# Altium schematic sheet power.SchDoc(power)
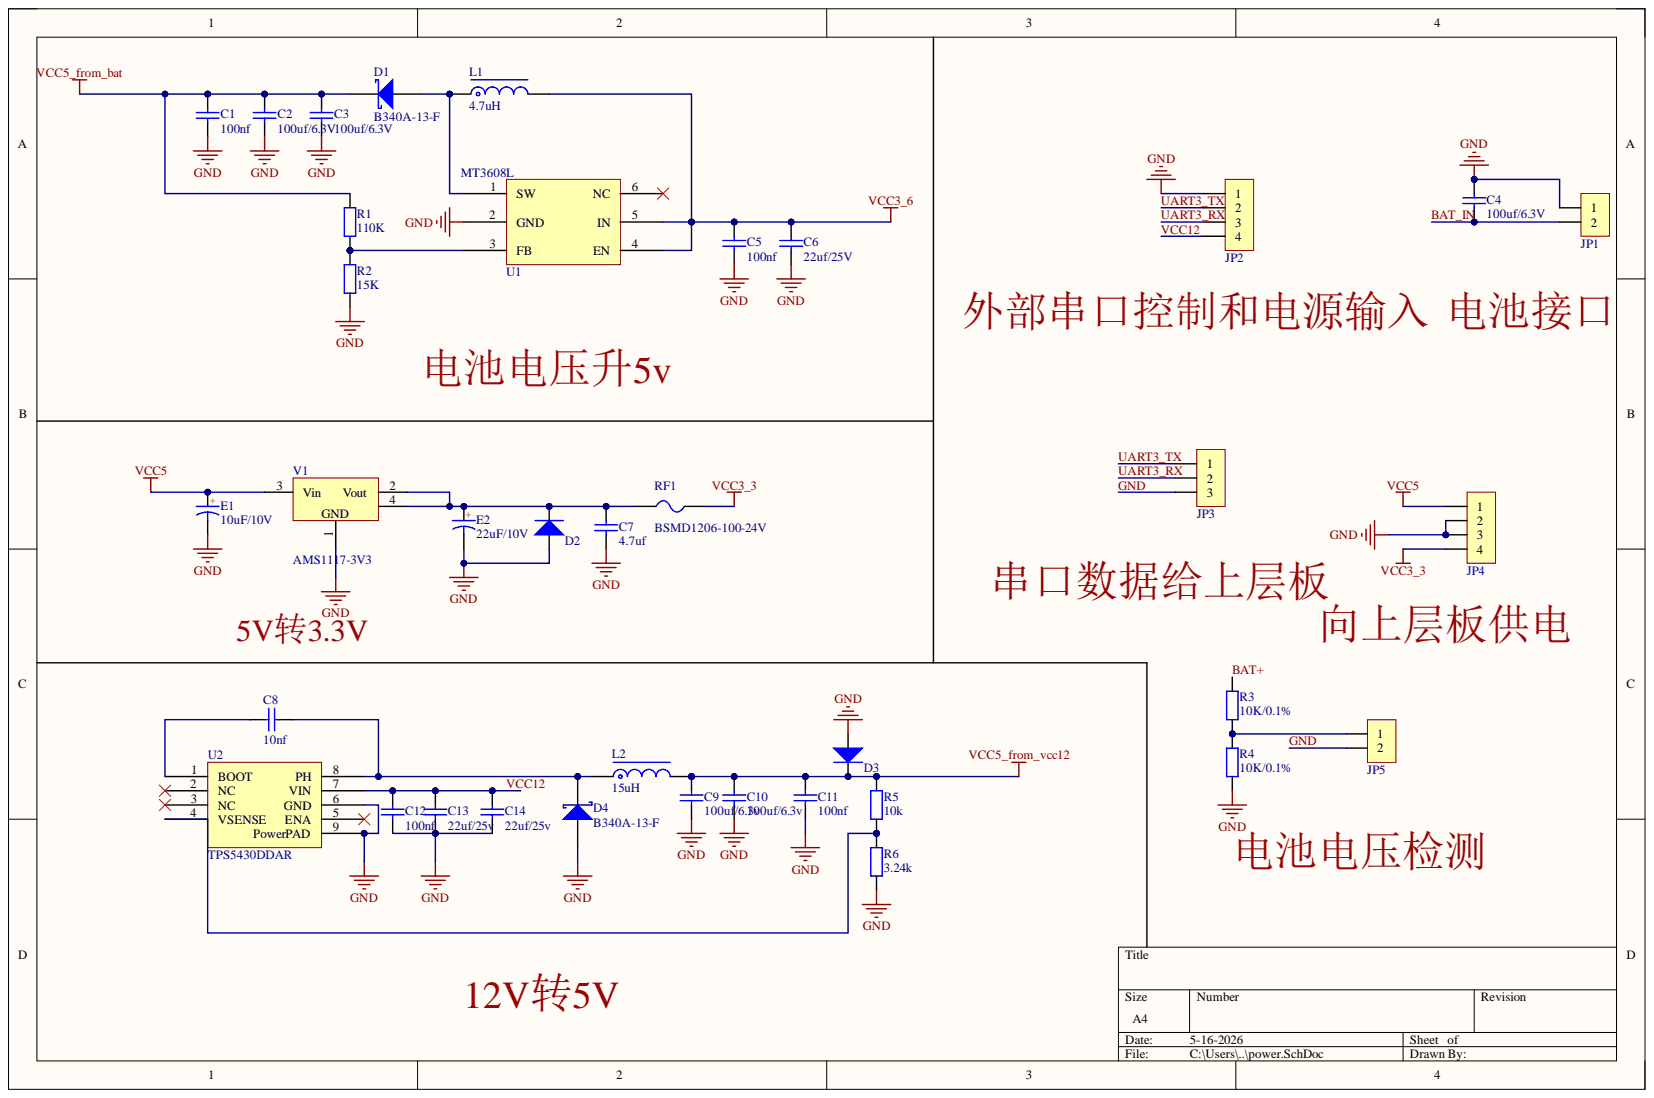
[diagram p0: power.SchDoc]

## Parts
C1  100nf  [C0603_L]
C2  100uf/6.3V  [C1206_L]
C3  100uf/6.3V  [C1206_L]
C4  100uf/6.3V  [C1206_L]
C5  100nf  [C0603_L]
C6  22uf/25V  [C1206_L]
C7  4.7uf  [C0603_M]
C8  10nf  [C0603_L]
C9  100uf/6.3v  [C1206_L]
C10  100uf/6.3v  [C1206_L]
C11  100nf  [C0603_L]
C12  100nf  [C0603_L]
C13  22uf/25v  [C1206_L]
C14  22uf/25v  [C1206_L]
D1  B340A-13-F  [SMA]
D2  UDD32C03L01  [SOD-323]  (value not drawn)
D3  SMF5.0A  [SOD-123]  (value not drawn)
D4  B340A-13-F  [SMA]
E1  10uF/10V  [C_3216]
E2  22uF/10V  [C_3216]
JP1  HDR-1X2  [PH2.0-W-2P]  (value not drawn)
JP2  HDR-1X4  [PH2.0-W-4P]  (value not drawn)
JP3  HDR-1X3  [HDR2.54-LI-3P]  (value not drawn)
JP4  HDR-1X4  [HDR2.54-LI-4P]  (value not drawn)
JP5  HDR-1X2  [HDR2.54-LI-2P]  (value not drawn)
L1  4.7uH  [CD53]
L2  15uH  [CD75]
R1  110K  [R0603_L]
R2  15K  [R0603_L]
R3  10K/0.1%  [R0603_L]
R4  10K/0.1%  [R0603_L]
R5  10k  [R0603_L]
R6  3.24k  [R0603_L]
RF1  BSMD1206-100-24V  [F1206]
U1  MT3608L  [SOT23-6M]
U2  TPS5430DDAR  [SOIC-8_EP]
V1  AMS1117-3V3  [SOT223_L]

## Nets
VCC3_3: JP4.4 RF1.1
VCC3_6: C5.2 C6.2 L1.2 U1.4(EN) U1.5(IN)
VCC5: E1.1 JP4.1 V1.3(Vin)
VCC5_from_bat: C1.2 C2.2 C3.2 D1.2 R1.2
VCC5_from_vcc12: C9.2 C10.2 C11.2 D3.2 L2.2 R5.2
BAT+: R3.2
BAT_IN: C4.1 JP1.2
GND: C1.1 C2.1 C3.1 C4.2 C5.1 C6.1 C7.2 C9.1 C10.1 C11.1 C12.1 C13.1 C14.1 D2.1 D3.1 D4.1 E1.2 E2.2 JP1.1 JP2.1 JP3.3 JP4.2 JP4.3 JP5.2 R2.1 R4.1 R6.1 U1.2(GND) U2.6(GND) U2.9(PowerPAD) V1.1
UART3_RX: JP2.3 JP3.2
UART3_TX: JP2.2 JP3.1
VCC12: C12.2 C13.2 C14.2 JP2.4 U2.7(VIN)
NetC7_1: C7.1 D2.2 E2.1 RF1.2 V1.2(Vout) V1.4
NetC8_1: C8.1 U2.1(BOOT)
NetC8_2: C8.2 D4.2 L2.1 U2.8(PH)
NetD1_1: D1.1 L1.1 U1.1(SW)
NetJP5_1: JP5.1 R3.1 R4.2
NetR1_1: R1.1 R2.2 U1.3(FB)
NetR5_1: R5.1 R6.2 U2.4(VSENSE)

Not connected: U1 6(NC); U2 2(NC) 3(NC) 5(ENA)

## Library data of the parts
C1-C6 = C: 贴片电容/MLCC电容; BOM名称: 无极性贴片电容; Component Kind: Standard; Library Name: 0.1 - 电阻-电容-电感-保险丝-晶振-晶体-磁珠-电位器-法拉电容-馈通电容.SchLib
  C2-C4: jlc_id: C15008
C7 C8 C11-C13 = C: 贴片电容/MLCC电容; BOM名称: 无极性贴片电容; 联系下单店铺卖家 免费帮你查找封装: 联系下单店铺卖家 免费技术指导; Component Kind: Standard; Library Name: 0.1 - 电阻-电容-电感-保险丝-晶振-晶体-磁珠-电位器-法拉电容-馈通电容.SchLib
C9 C10 = C: 贴片电容/MLCC电容 C15008; BOM名称: 无极性贴片电容; 联系下单店铺卖家 免费帮你查找封装: 联系下单店铺卖家 免费技术指导; Component Kind: Standard; Library Name: 0.1 - 电阻-电容-电感-保险丝-晶振-晶体-磁珠-电位器-法拉电容-馈通电容.SchLib
C14 = C: 贴片电容/MLCC电容 C12891; BOM名称: 无极性贴片电容; 联系下单店铺卖家 免费帮你查找封装: 联系下单店铺卖家 免费技术指导; Component Kind: Standard; Library Name: 0.1 - 电阻-电容-电感-保险丝-晶振-晶体-磁珠-电位器-法拉电容-馈通电容.SchLib
D1 = B340A-13-F: 肖特基二极管 C85098; 属性: 60V/5A; BOM名称: 肖特基二极管; Component Kind: Standard; Library Name: HT.SchLib
D2 = TVS: TVS通用 C78439; 当前库版本V2024.3.30 @淘宝卖家: 最新版本发布https://item.taobao.com/item.htm?id=566775463719; Component Kind: Standard; Library Name: 0.2 - 二极管-TVS-ESD-整流桥-放电管.SchLib
D3 = TVS: TVS通用; 当前库版本V2024.3.30 @淘宝卖家: 最新版本发布https://item.taobao.com/item.htm?id=566775463719; Component Kind: Standard; Library Name: 0.2 - 二极管-TVS-ESD-整流桥-放电管.SchLib
D4 = B340A-13-F: 肖特基二极管 C85098; 属性: 60V/5A; 当前库版本V2024.3.30 @淘宝卖家: 最新版本发布https://item.taobao.com/item.htm?id=566775463719; BOM名称: 肖特基二极管; Component Kind: Standard; Library Name: HT.SchLib
E1 = CT: 贴片钽电容 C7171; 联系下单店铺卖家 免费帮你查找封装: 联系下单店铺卖家 免费技术指导; BOM名称: 贴片钽电容; Component Kind: Standard; Library Name: 0.1 - 电阻-电容-电感-保险丝-晶振-晶体-磁珠-电位器-法拉电容-馈通电容.SchLib
E2 = CT: 贴片钽电容  C11366; 联系下单店铺卖家 免费帮你查找封装: 联系下单店铺卖家 免费技术指导; BOM名称: 贴片钽电容; Component Kind: Standard; Library Name: 0.1 - 电阻-电容-电感-保险丝-晶振-晶体-磁珠-电位器-法拉电容-馈通电容.SchLib
JP1 = Header 2: 2P接插件; 封装库来自淘宝卖家：黄晶宝石: 获取最新版本https://shop463601444.taobao.com; 联系下单店铺卖家 免费帮你查找封装: 联系下单店铺卖家 免费技术支持; BOM名称: 2P接插件; Component Kind: Standard; Library Name: 5.4 - 2.0mm连接器(PHB2.0_PHD2.0_2.0排针排母).SchLib
JP2 = Header 4: 4P接插件; 封装库来自淘宝卖家：黄晶宝石: 获取最新版本https://shop463601444.taobao.com; 联系下单店铺卖家 免费帮你查找封装: 联系下单店铺卖家 免费技术支持; Component Kind: Standard; Library Name: 5.4 - 2.0mm连接器(PHB2.0_PHD2.0_2.0排针排母).SchLib
JP3 = Header 3: 3P接插件; 封装库来自淘宝卖家ID：黄晶宝石: 其他店铺购入均为盗版封装库，请立即申请退款，本店现金奖励50~200元; 联系卖家，免费查找封装: 唯一出售店铺网址https://shop463601444.taobao.com; BOM名称: 3P接插件; Component Kind: Standard; Library Name: 5.5 - 2.54mm连接器(KF2EDGK_XH2.54_2.54排针排母).SchLib
JP4 = Header 4: 4P接插件; 封装库来自淘宝卖家ID：黄晶宝石: 其他店铺购入均为盗版封装库，请立即申请退款，本店现金奖励50~200元; 联系卖家，免费查找封装: 唯一出售店铺网址https://shop463601444.taobao.com; BOM名称: 4P接插件; Component Kind: Standard; Library Name: 5.5 - 2.54mm连接器(KF2EDGK_XH2.54_2.54排针排母).SchLib
JP5 = Header 2: 2P接插件; 封装库来自淘宝卖家ID：黄晶宝石: 其他店铺购入均为盗版封装库，请立即申请退款，本店现金奖励50~200元; 联系卖家，免费查找封装: 唯一出售店铺网址https://shop463601444.taobao.com; BOM名称: 2P接插件; Component Kind: Standard; Library Name: 5.5 - 2.54mm连接器(KF2EDGK_XH2.54_2.54排针排母).SchLib
L1 L2 = L-SMD: CD系列贴片线绕功率电感; BOM名称: 功率电感; Component Kind: Standard; Library Name: 0.1 - 电阻-电容-电感-保险丝-晶振-晶体-磁珠-电位器-法拉电容-馈通电容.SchLib
  L1: jlc_id: C177246
  L2: jlc_id: C177243
R1-R6 = R: 贴片电阻; 联系下单店铺卖家 免费帮你查找封装: 联系下单店铺卖家 免费技术指导; BOM名称: 贴片电阻; Component Kind: Standard; Library Name: 0.1 - 电阻-电容-电感-保险丝-晶振-晶体-磁珠-电位器-法拉电容-馈通电容.SchLib
RF1 = FUSE-PPTC: PPTC自恢复保险丝 C910830; 当前库版本V2024.3.30 @淘宝卖家: 最新版本发布https://item.taobao.com/item.htm?id=566775463719; BOM名称: PPTC自恢复保险丝; Component Kind: Standard; Library Name: 0.1 - 电阻-电容-电感-保险丝-晶振-晶体-磁珠-电位器-法拉电容-馈通电容.SchLib
U1 = MT3608L: Boost升压DC-DC芯片 2-28V; Component Kind: Standard; Library Name: HT.SchLib
U2 = TPS5430DDAR: 5.5v到36v转1.3v到32v; Component Kind: Standard; Library Name: HT.SchLib
V1 = AMS1117: 三端稳压芯片; BOM名称: 稳压芯片; 当前库版本V2024.3.30 @淘宝卖家: 最新版本发布https://item.taobao.com/item.htm?id=566775463719; 联系下单店铺卖家 免费帮你查找封装: 联系下单店铺卖家 免费技术支持; Component Kind: Standard; Library Name: 0.7 - 电源类芯片.SchLib
